# Altium schematic sheet 231006.SchDoc(231006)
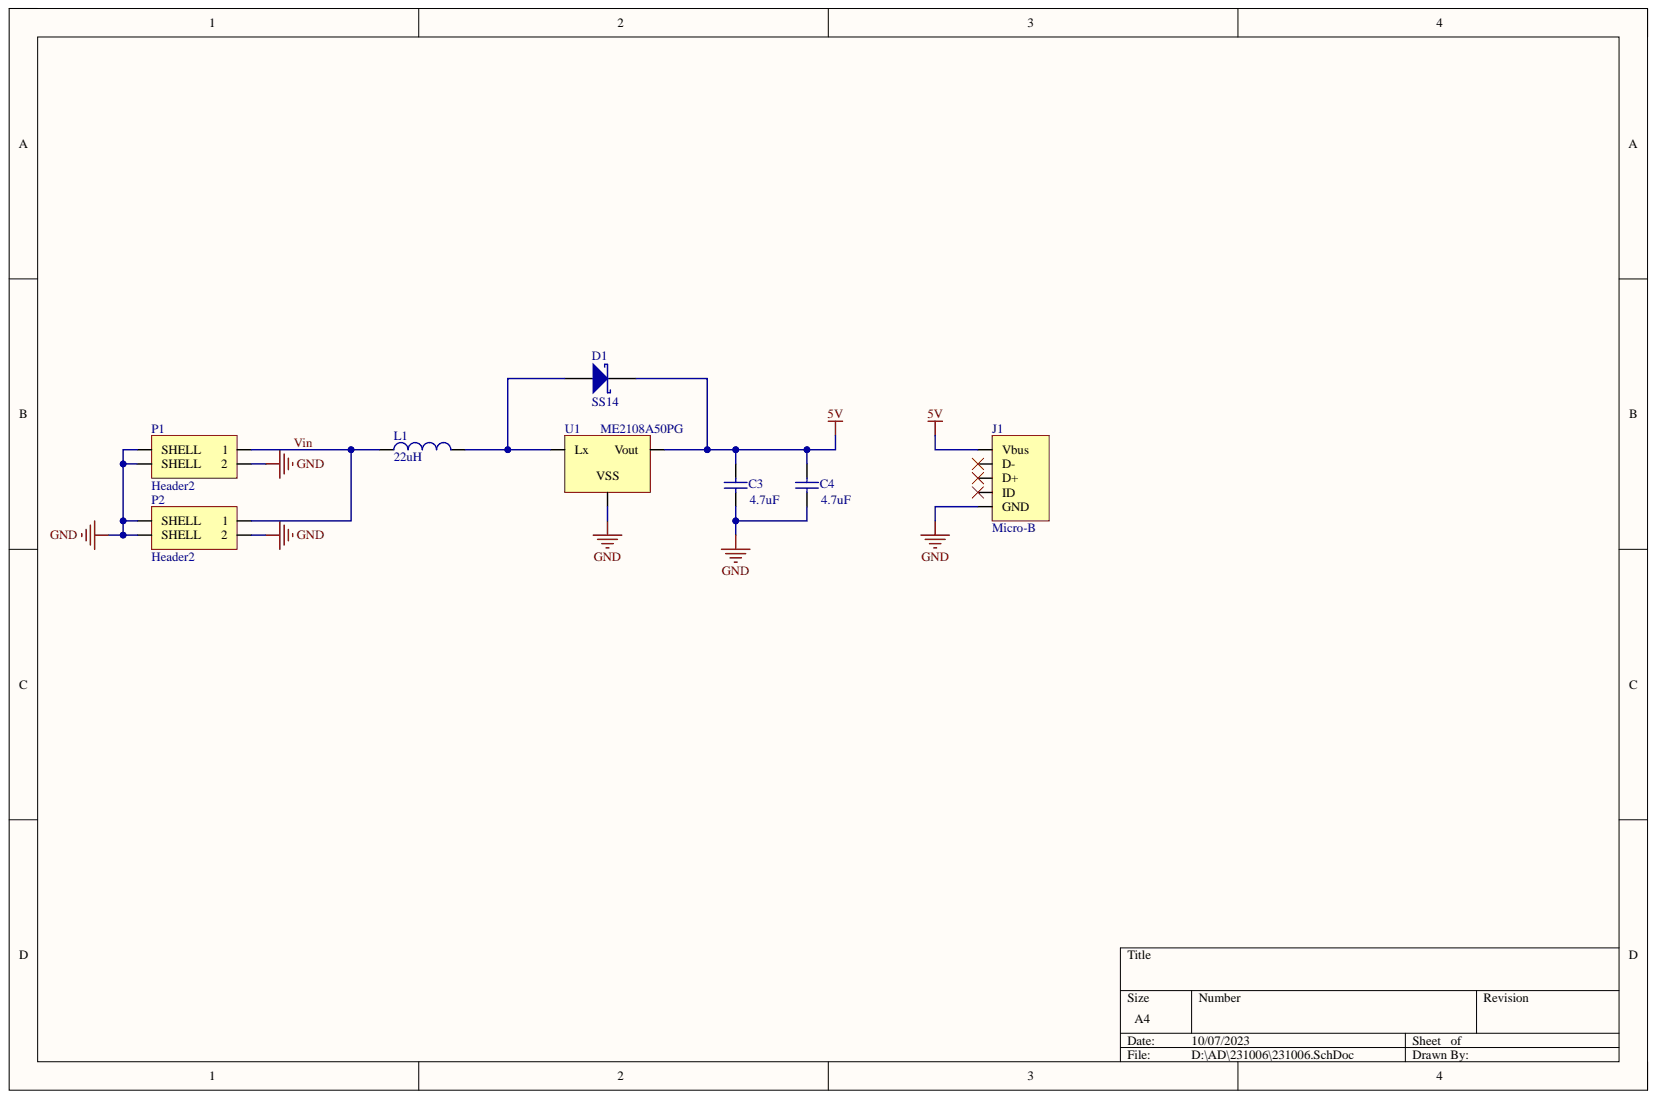
[diagram p0: 231006.SchDoc]

## Parts
C3  4.7uF  [1206]
C4  4.7uF  [1206]
D1  SS14  [SOD_234]
J1  Micro-B  [USB-MICRO_A]
L1  22uH  [L_4.5X6]
P1  Header2  [mh1.25-2P]
P2  Header2  [mh1.25-2P]
U1  ME2108A50PG  [SOT89]

## Nets
5V: C3.2 C4.2 D1.1 J1.1(Vbus) U1.2
GND: C3.1 C4.1 J1.5(GND) P1.0 P1.2 P2.0 P2.2 U1.1
Vin: L1.1 P1.1 P2.1
NetD1_2: D1.2 L1.2 U1.3

Not connected: J1 2(D-) 3(D+) 4(ID)

## Library data of the parts
C3 C4 = Cap: Capacitor; Component Kind: Standard; LatestRevisionDate: 17-Jul-2002; LatestRevisionNote: Re-released for DXP Platform.; Library Name: Miscellaneous Devices.IntLib; PackageDescription: Radial Cap, Thru-Hole; 2 Leads; 0.3 in Pin Spacing; PackageReference: RAD-0.3; Published: 8-Jun-2000; Signal Integrity: Cap; Simulation: CAP; Value: 4.7uF; Publisher: Altium Limited
D1 = Diode 10TQ035: Schottky Rectifier; Note: Terminal 2 is the TAB and is electrically connected to the cathode; Component Kind: Standard; ComponentLink1Description: Manufacturer Link; ComponentLink1URL: http://www.irf.com/; ComponentLink2Description: Datasheet; ComponentLink2URL: http://www.irf.com/product-info/datasheets/data/10tq.pdf; DatasheetVersion: PD-2.057B; LatestRevisionDate: 17-Jul-2002; LatestRevisionNote: Re-released for DXP Platform.; Library Name: Miscellaneous Devices.IntLib; PackageDescription: TO, Thru-Hole, Vertical, Heatsink Mounted; 3 In-Line Leads (1 Absent); Pitch 2.54 mm; PackageReference: TO-220AC; PackageVersion: Feb-1998; Published: 8-Jun-2000; Simulation: 10TQ035; Publisher: Altium Limited
L1 = Inductor: Code_IEC: 1005; Code_JEITA: 0402; Component Kind: Standard; Description: Inductor; LatestRevisionDate: 29-May-2009; LatestRevisionNote: IPC-7351 Footprint Added.; Library Name: Miscellaneous Devices.IntLib; PackageDescription: Chip Inductor; PackageReference: 0402-A; Published: 8-Jun-2000; Signal Integrity: Inductor; Simulation: INDUCTOR; Value: 22uH; Publisher: Altium Limited
J1 = Micro-B: Component Kind: Standard; Library Name: 231006.SchLib
P1 P2 = Header2: Component Kind: Standard; Library Name: 231006.SchLib
U1 = ME2108A50PG: Component Kind: Standard; Library Name: 231006.SchLib
